# Altium schematic sheet main.SchDoc
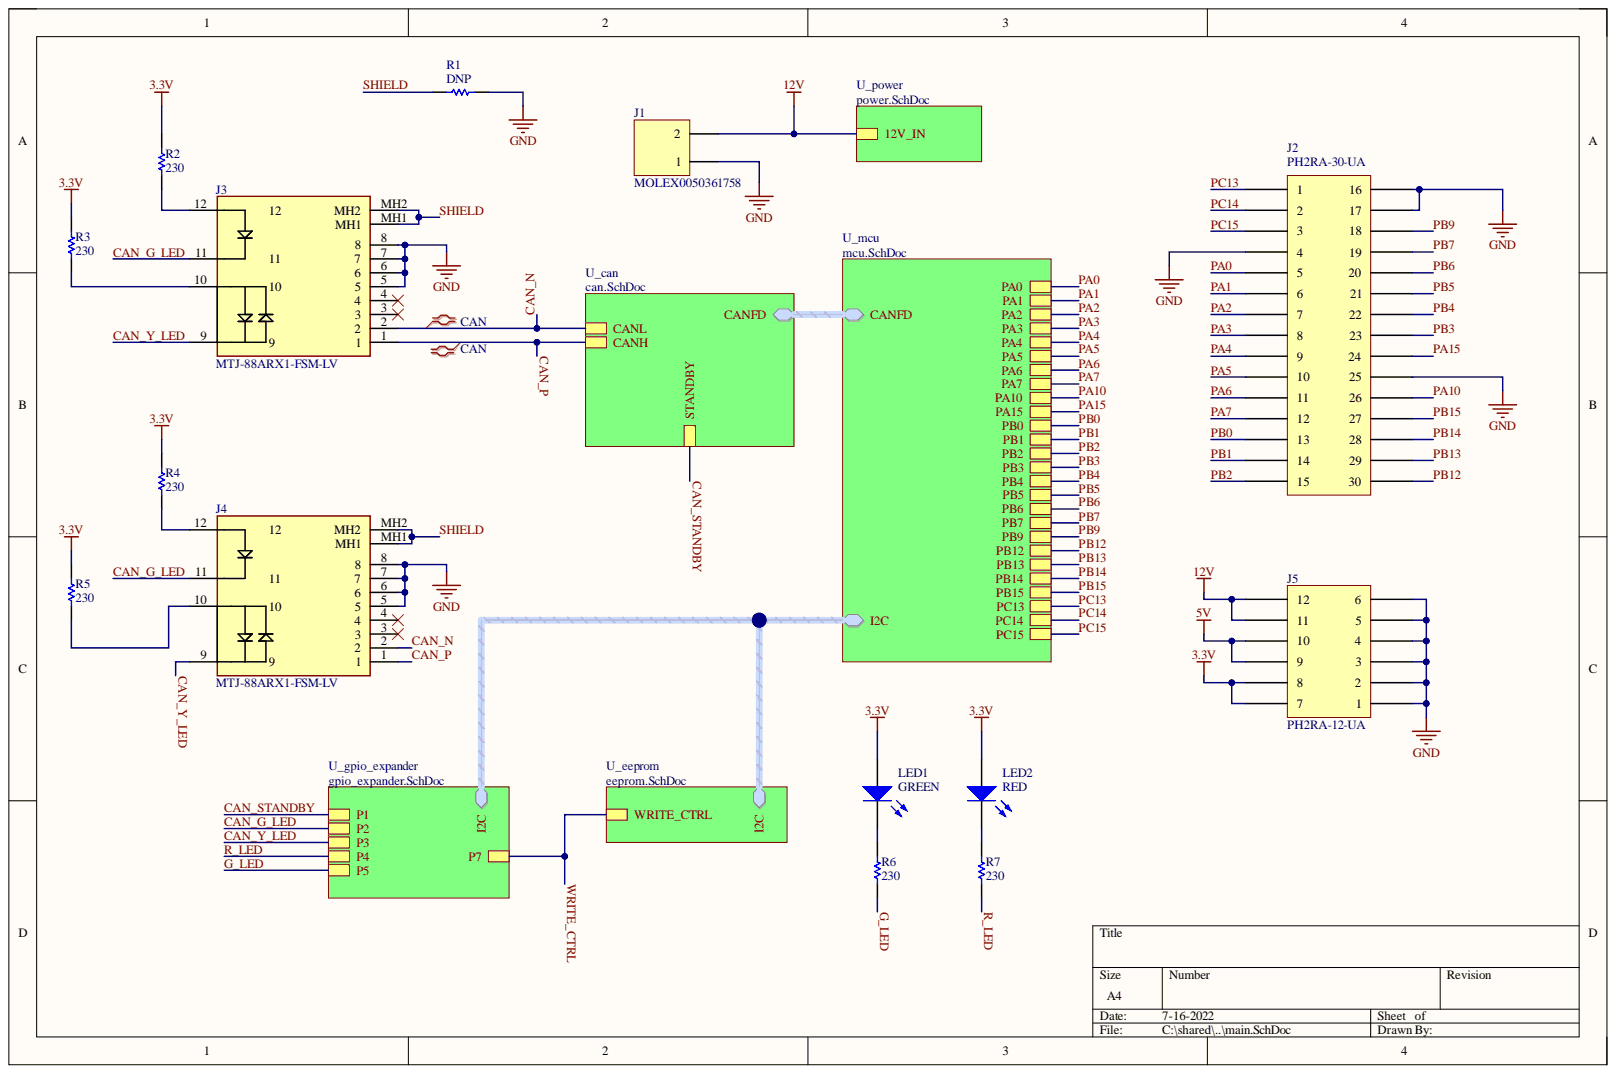
[diagram p0: main.SchDoc]

## Parts
J1  MOLEX0050361758  [Molex0050361758]
J2  PH2RA-30-UA  [PH2RA-30-UA]
J3  MTJ-88ARX1-FSM-LV  [MTJ-88ARX1-FSM-LV]
J4  MTJ-88ARX1-FSM-LV  [MTJ-88ARX1-FSM-LV]
J5  PH2RA-12-UA  [PH2RA-12-UA]
LED1  GREEN  [0805 - DIODE]
LED2  RED  [0805 - DIODE]
R1  value DNP  [0603 - Resistor]  (hidden comment: Resistor)
R2  value 230  [0603 - Resistor]  (hidden comment: Resistor)
R3  value 230  [0603 - Resistor]  (hidden comment: Resistor)
R4  value 230  [0603 - Resistor]  (hidden comment: Resistor)
R5  value 230  [0603 - Resistor]  (hidden comment: Resistor)
R6  value 230  [0603 - Resistor]  (hidden comment: Resistor)
R7  value 230  [0603 - Resistor]  (hidden comment: Resistor)

## Nets
3.3V: J5.7 J5.8 LED1.1 LED2.1 R2.1 R3.1 R4.1 R5.1
5V: J5.9 J5.10
12V: J1.2 J5.11 J5.12
GND: J1.1 J2.4 J2.16 J2.17 J2.25 J3.5 J3.6 J3.7 J3.8 J4.5 J4.6 J4.7 J4.8 J5.1 J5.2 J5.3 J5.4 J5.5 J5.6 R1.1
CAN_G_LED: J3.11 J4.11
CAN_N: J3.2 J4.2
CAN_P: J3.1 J4.1
CAN_Y_LED: J3.9 J4.9
G_LED: R6.2
PA0: J2.5
PA1: J2.6
PA2: J2.7
PA3: J2.8
PA4: J2.9
PA5: J2.10
PA6: J2.11
PA7: J2.12
PA10: J2.26
PA15: J2.24
PB0: J2.13
PB1: J2.14
PB2: J2.15
PB3: J2.23
PB4: J2.22
PB5: J2.21
PB6: J2.20
PB7: J2.19
PB9: J2.18
PB12: J2.30
PB13: J2.29
PB14: J2.28
PB15: J2.27
PC13: J2.1
PC14: J2.2
PC15: J2.3
R_LED: R7.2
SHIELD: J3.MH1 J3.MH2 J4.MH1 J4.MH2 R1.2
NetJ3_3: J3.3
NetJ3_4: J3.4
NetJ3_10: J3.10 R3.2
NetJ3_12: J3.12 R2.2
NetJ4_3: J4.3
NetJ4_4: J4.4
NetJ4_10: J4.10 R5.2
NetJ4_12: J4.12 R4.2
NetLED1_2: LED1.2 R6.1
NetLED2_2: LED2.2 R7.1
CAN_STANDBY:
CANFD.RX:
CANFD.TX:
I2C.SCL:
I2C.SDA:
WRITE_CTRL:

## Library data of the parts
J1 = MOLEX0050361758: Connector Header Through Hole, Right Angle 2 position -; Manufacturer: Molex; Component Kind: Standard; ComponentLink1Description: Datasheet; ComponentLink1URL: https://www.molex.com/pdm_docs/ps/PS-5556-001.pdf; Library Name: GeneralConnectors.SchLib; Manufacturer Part Number: 0050361758; Supplier: DigiKey; Supplier Part Number: 0050361758-ND
J2 = PH2RA-30-UA: Connector Header Through Hole, Right Angle 30 position 0.100" (2.54mm); Manufacturer: Adam Tech; Component Kind: Standard; ComponentLink1Description: Datasheet; ComponentLink1URL: https://app.adam-tech.com/products/download/data_sheet/200508/ph2ra-xx-ua-data-sheet.pdf; Library Name: GeneralConnectors.SchLib; Manufacturer Part Number: PH2RA-30-UA; Supplier: DigiKey; Supplier Part Number: 2057-PH2RA-30-UA-ND
J3 J4 = MTJ-88ARX1-FSM-LV: RJ45 Connector; Component Kind: Standard; Library Name: GeneralConnectors.SchLib
J5 = PH2RA-12-UA: Connector Header Through Hole, Right Angle 12 position 0.100" (2.54mm); Manufacturer: Adam Tech; Manufacturer Part Number: PH2RA-30-UA; Component Kind: Standard; ComponentLink1Description: Datasheet; ComponentLink1URL: https://app.adam-tech.com/products/download/data_sheet/200508/ph2ra-xx-ua-data-sheet.pdf; Library Name: GeneralConnectors.SchLib; Supplier: DigiKey; Supplier Part Number: 2057-PH2RA-30-UA-ND
LED1 LED2 = LED: Light Emitting Diode; Component Kind: Standard; Library Name: General.SchLib; Simulation: LED0
R1-R7 = Resistor: Component Kind: Standard; Description: Resistor; Library Name: General.SchLib; Signal Integrity: Res; Simulation: RESISTOR
